# Altium schematic sheet Frequency sound amplifier_Schematic.SchDoc("Frequency sound amplifier_Schematic")
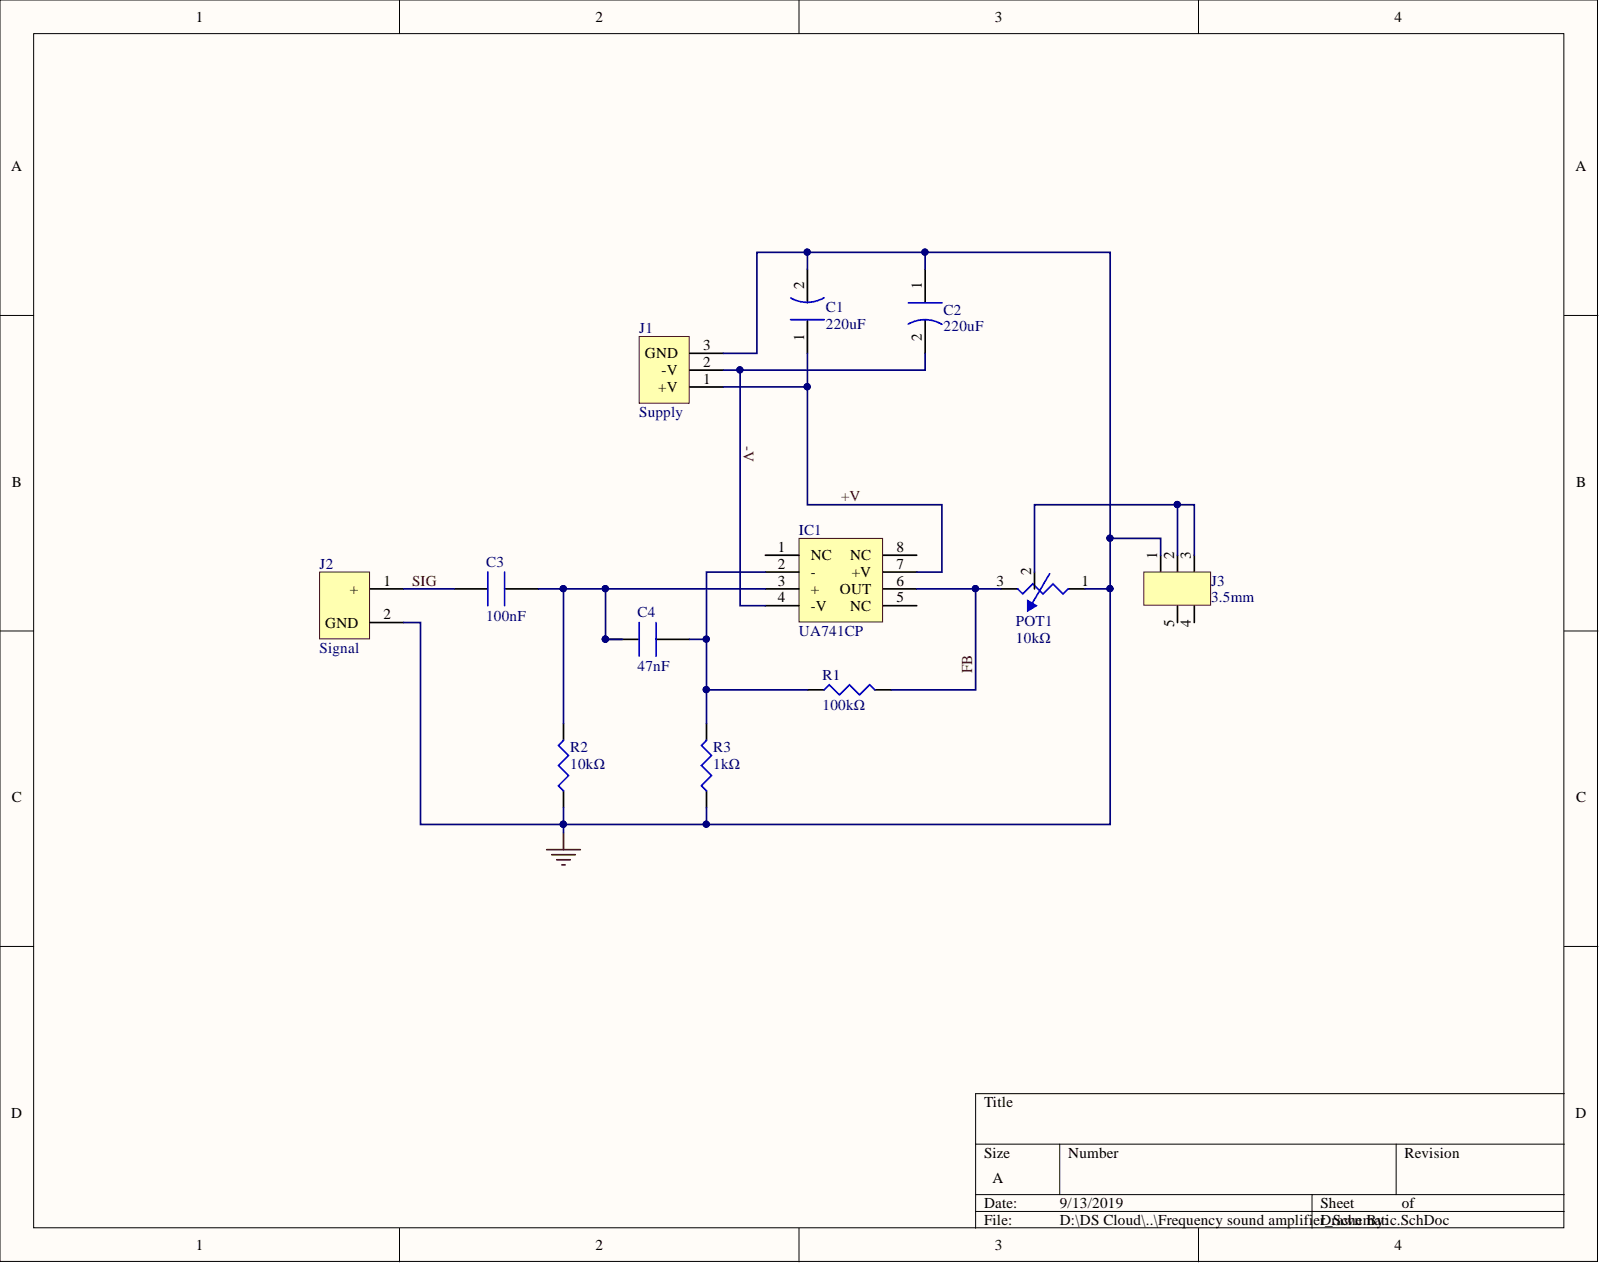
[diagram p0: Frequency sound amplifier_Schematic.SchDoc]

## Parts
C1  220uF  [220u]
C2  220uF  [220u]
C3  100nF  [100n]
C4  47nF  [47n]
IC1  UA741CP  [UE741]
J1  Supply  [Con3]
J2  Signal  [Con2]
J3  3.5mm  [3.5mm]
POT1  10kΩ  [Pot]
R1  100kΩ  [100k]
R2  10kΩ  [10K]
R3  1kΩ  [1k]

## Nets
GND: C1.2 C2.1 J1.3 J2.2 J3.1 POT1.1 R2.1 R3.1
+V: C1.1 IC1.7(+V) J1.1
-V: C2.2 IC1.4(-V) J1.2
FB: IC1.6(OUT) POT1.3 R1.2
SIG: C3.1 J2.1
NetC3_2: C3.2 C4.1 IC1.3(+) R2.2
NetC4_2: C4.2 IC1.2(-) R1.1 R3.2
NetJ3_2: J3.2 J3.3 POT1.2

Not connected: IC1 1(NC) 5(NC) 8(NC); J3 4 5

## Library data of the parts
C1 C2 = UVR1E221MPD1TA: Aluminum Electrolytic Capacitors - Leaded 25volts 220uF; Manufacturer: Nichicon; Voltage Rating (DC): 25V; Tolerance: 20%; Ripple Current: 330mA; Life (Hours): 2000Hour; Leakage Current: 165uA; Dissipation Factor: 0.16%; Dielectric Material: Aluminium; Voltage Rating: 25V; Termination: Radial; Supplier Part Number 1: 493-6097-1-ND; Supplier 1: Digi-Key; Stock 1: 2078; RoHS: Yes; Pricing 1: 1 = 0.29000, 10 = 0.20200, 100 = 0.12140, 500 = 0.09108 (USD); Price Per Unit: 0.29; Packaging: Tape and Reel; Package Quantity: 1; Number of Pins: 2; Mount: Through Hole; Min Operating Temperature: -40°C; Max Operating Temperature: 85°C; Manufacturer Part Number: UVR1E221MPD1TA; Length: 11.5mm; Lead Pitch: 0.197inch; Lead Free: Lead Free; Height - Seated (Max): 0.512inch; Features: General Purpose; ComponentLink2URL: https://datasheet.ciiva.com/6299/e-vr-6299007.pdf?src-supplier=Digi-Key; ComponentLink2Description: https://datasheet.ciiva.com/6299/e-vr-6299007.pdf?src-supplier=Digi-Key; ComponentLink1URL: https://datasheet.ciiva.com/16502/e-vr-16502829.pdf?src-supplier=Future+Electronics; ComponentLink1Description: https://datasheet.ciiva.com/16502/e-vr-16502829.pdf?src-supplier=Future+Electronics; Component Kind: Standard; Category: Capacitors; Case/Package: Radial; Capacitance: 220uF; Library Name: Frequency sound amplifier_SchematicLib.SchLib
C3 = C320C104K5R5TA7301: Cap Ceramic 0.1uF 50V X7R 10% Radial 2.54mm 125Â°C T/R; Manufacturer: KEMET; Lead Diameter: 0.51mm; Lead/Base Style: Straight; Dissipation Factor: 2.5%; Width: 5.08mm; Voltage Rating (DC): 50V; Voltage Rating: 50V; Tolerance: 10%; Termination: Radial; Supplier Part Number 1: 399-9870-1-ND; Supplier 1: Digi-Key; Stock 1: 99779; RoHS: Yes; Radiation Hardening: No; Pricing 1: 1 = 0.25000, 10 = 0.17300, 100 = 0.09780, 500 = 0.06900, 1000 = 0.06038 (USD); Price Per Unit: 0.25; Pitch: 2.54mm; Packaging: Tape and Reel; Package Quantity: 2500; Mount: Through Hole; Min Operating Temperature: -55°C; Max Operating Temperature: 125°C; Manufacturer Part Number: C320C104K5R5TA7301; Length: 5.08mm; Lead Pitch: 2.54mm; Height - Seated (Max): 0.26inch; Height: 3.18mm; Dielectric: X7R; Depth: 3.18mm; Contact Plating: Tin,Matte; ComponentLink2URL: https://datasheet.ciiva.com/6276/f3101-goldmax-6276795.pdf?src-supplier=Digi-Key; ComponentLink2Description: https://datasheet.ciiva.com/6276/f3101-goldmax-6276795.pdf?src-supplier=Digi-Key; ComponentLink1URL: https://datasheet.ciiva.com/6276/f3101-goldmax-6276793.pdf?src-supplier=Digi-Key; ComponentLink1Description: https://datasheet.ciiva.com/6276/f3101-goldmax-6276793.pdf?src-supplier=Digi-Key; Component Kind: Standard; Category: Capacitors; Case/Package: Dipped; Capacitance: 100nF; Library Name: Frequency sound amplifier_SchematicLib.SchLib
C4 = C315C473M5U5TA: CAP CER 0.047UF 50V Z5U RADIAL; Manufacturer: KEMET; Tolerance: 20%; Temperature Coefficient: 7.5ppm/°C; Lead Length: 7mm; Lead Diameter: 0.51mm; Lead/Base Style: Straight; Dissipation Factor: 4%; Dielectric Material: Ceramic; Width: 3.81mm; Voltage Rating (DC): 50V; Voltage Rating: 50V; Voltage: 50V; Termination: Radial; Supplier Part Number 1: 399-4189-ND; Supplier 1: Digi-Key; Stock 1: 1403; RoHS: Yes; REACH SVHC: No SVHC; Radiation Hardening: No; Pricing 1: 1 = 0.33000, 10 = 0.22800, 100 = 0.12920, 500 = 0.09120, 1000 = 0.07980, 2500 = 0.07600, 5000 = 0.07030 (USD); Price Per Unit: 0.33; Packaging: Bulk; Package Quantity: 500; Number of Pins: 2; Mount: Through Hole,PCB; Min Operating Temperature: -55°C; Max Operating Temperature: 85°C; Manufacturer Part Number: C315C473M5U5TA; Length: 3.81mm; Lead Pitch: 2.54mm; Lead Free: Lead Free; Height - Seated (Max): 0.21inch; Height: 2.54mm; Dielectric: Z5U; Depth: 2.54mm; Composition: Ceramic; ComponentLink9URL: https://search.kemet.com/component-edge/download/datasheet/C315C473M5U5TA.pdf?src-supplier=Digi-Key; ComponentLink9Description: https://search.kemet.com/component-edge/download/datasheet/C315C473M5U5TA.pdf?src-supplier=Digi-Key; ComponentLink8URL: http://capacitoredge.kemet.com/capedge2/DataSheet?pn=C315C473M5U5CA&src-supplier=Avnet; ComponentLink8Description: http://capacitoredge.kemet.com/capedge2/DataSheet?pn=C315C473M5U5CA&src-supplier=Avnet; ComponentLink7URL: http://capacitoredge.kemet.com/capedge2/DataSheet?pn=C315C473M5U5CA&src-supplier=Avnet+Europe; ComponentLink7Description: http://capacitoredge.kemet.com/capedge2/DataSheet?pn=C315C473M5U5CA&src-supplier=Avnet+Europe; ComponentLink6URL: http://www.kemet.com/kemet/web/homepage/kechome.nsf/file/Ceramic%20Conformally%20Coated%20Radial%20Standard%20and%20High%20Voltage%20Golden%20Max/$file/F3101_goldmax.pdf?src-supplier=Element14; ComponentLink6Description: http://www.kemet.com/kemet/web/homepage/kechome.nsf/file/Ceramic%20Conformally%20Coated%20Radial%20Standard%20and%20High%20Voltage%20Golden%20Max/$file/F3101_goldmax.pdf?src-supplier=Element14; ComponentLink5URL: http://components.arrow.com/part/dataview/42164590S8620817N7429?src-supplier=Arrow; ComponentLink5Description: http://components.arrow.com/part/dataview/42164590S8620817N7429?src-supplier=Arrow; ComponentLink4URL: http://uk.rs-online.com/webdocs/13da/0900766b813da575.pdf?src-supplier=RSComponents; ComponentLink4Description: http://uk.rs-online.com/webdocs/13da/0900766b813da575.pdf?src-supplier=RSComponents; ComponentLink3URL: https://www.alliedelec.com/m/d/fcfab52016b7968de19fc4f2d27edd4c.pdf?src-supplier=Allied+Electronics; ComponentLink3Description: https://www.alliedelec.com/m/d/fcfab52016b7968de19fc4f2d27edd4c.pdf?src-supplier=Allied+Electronics; ComponentLink2URL: http://www.farnell.com/datasheets/706880.pdf?src-supplier=Farnell; ComponentLink2Description: http://www.farnell.com/datasheets/706880.pdf?src-supplier=Farnell; ComponentLink1URL: http://www.mouser.com/ds/2/212/KEM_C1051_GOLDMAX_Z5U-1140498.pdf?src-supplier=Mouser; ComponentLink1Description: http://www.mouser.com/ds/2/212/KEM_C1051_GOLDMAX_Z5U-1140498.pdf?src-supplier=Mouser; Component Kind: Standard; Category: Capacitors; Case/Package: Radial; Capacitance: 47nF; Library Name: Frequency sound amplifier_SchematicLib.SchLib
IC1 = LM741CN/NOPB: Operational amplifier; 1.5MHz; 10÷36V; Channels: 1; DIP8; Manufacturer: TI National Semiconductor; Voltage Gain: 106.02dB; Voltage: 36V; Slew Rate: 0.5V/µs; Power Supply Rejection Ratio (PSRR): 77dB; Power Dissipation: 500mW; Output Current per Channel: 25mA; Operating Supply Current: 1.7mA; Number of Elements: 1; Min Supply Voltage: 10V; Min Dual Supply Voltage: 5V; Max Supply Voltage: 18V; Max Supply Current: 2.8mA; Input Offset Voltage (Vos): 2mV; Input Bias Current: 500nA; Gain: 96dB; Common Mode Rejection Ratio: 70dB; Width: 6.35mm; Weight: 0.01lb; Supplier Part Number 1: LM741CNNS/NOPB-ND; Supplier 1: Digi-Key; Stock 1: 9440; RoHS: Yes; REACH SVHC: No SVHC; Radiation Hardening: No; Pricing 1: 1 = 0.87000, 10 = 0.76600, 25 = 0.71920, 100 = 0.58700, 500 = 0.46400, 1000 = 0.37120, 2500 = 0.33640, 5000 = 0.31320 (USD); Price Per Unit: 0.87; Packaging: Rail/Tube; Package Quantity: 40; Output Current: 25mA; Number of Pins: 8; Number of Circuits: 1; Number of Channels: 1; Number of Amplifiers: 1; Nominal Supply Current: 1.7mA; Mount: Through Hole; Min Operating Temperature: 0°C; Max Power Dissipation: 500mW; Max Operating Temperature: 70°C; Max Dual Supply Voltage: 18V; Manufacturer Part Number: LM741CN/NOPB; Length: 9.27mm; Lead Free: Lead Free; Height: 3.3mm; Gain Bandwidth Product: 1.5MHz; Contact Plating: Tin,Matte; ComponentLink4URL: https://datasheet.ciiva.com/14151/mflm741-data-e-14151370.pdf?src-supplier=Distrelec; ComponentLink4Description: https://datasheet.ciiva.com/14151/mflm741-data-e-14151370.pdf?src-supplier=Distrelec; ComponentLink3URL: https://datasheet.ciiva.com/8008/1862441-8008933.pdf?src-supplier=Element14; ComponentLink3Description: https://datasheet.ciiva.com/8008/1862441-8008933.pdf?src-supplier=Element14; ComponentLink2URL: https://datasheet.ciiva.com/26325/175935-da-01-en-ic-lm-741cn-nopb-dip8-tid-26325918.pdf?src-supplier=Conrad; ComponentLink2Description: https://datasheet.ciiva.com/26325/175935-da-01-en-ic-lm-741cn-nopb-dip8-tid-26325918.pdf?src-supplier=Conrad; ComponentLink1URL: https://datasheet.ciiva.com/26850/lm741cn-nopb-26850677.pdf?src-supplier=IIIC; ComponentLink1Description: https://datasheet.ciiva.com/26850/lm741cn-nopb-26850677.pdf?src-supplier=IIIC; Component Kind: Standard; Category: IC; Case/Package: DIP; Bandwidth: 1.5MHz; Library Name: Frequency sound amplifier_SchematicLib.SchLib
J1 = 282834-3: TERM BLK 3P SIDE ENT 2.54MM PCB; Manufacturer: TE Connectivity; Wire Gauge (Min): 30AWG; Voltage Rating: 150V; Thread Size: M1.6; Tail Length: 3.4mm; Side Stackable: Yes (With); Screw Flange: No (Without); Plating: Brass,Steel; Number of Positions Per Level: 3; Number of Levels: 1; Max Current Rating: 10A; Connector Type: Receptacle; Approvals: UL; Wire Gauge (Max): 30AWG; Wire/Cable Gauge: 30AWG; Width: 10mm; Voltage: 150V; Termination: Solder; Supplier Part Number 1: A98334-ND; Supplier 1: Digi-Key; Stock 1: 3174; Screwless Terminal Block: Yes (With); RoHS: Yes; REACH SVHC: Unknown; Radiation Hardening: No; Pricing 1: 1 = 2.01000, 10 = 1.52300, 25 = 1.41160, 50 = 1.26920, 100 = 1.23820, 250 = 1.08340, 500 = 0.99052, 1000 = 0.89767, 5000 = 0.78933 (USD); Price Per Unit: 2.01; Pitch: 2.54mm; Packaging: Bulk; Package Quantity: 200; Orientation: Straight; Number of Rows: 1; Number of Positions: 3; Number of Poles: 3; Number of Contacts: 3; Number of Circuits: 3; Mount: Through Hole; Min Operating Temperature: -40°C; Max Voltage Rating (AC): 150V; Max Supply Voltage (AC): 150V; Max Operating Temperature: 105°C; Manufacturer Part Number: 282834-3; Length: 8.08mm; Lead Free: Lead Free; Interlock: No (Without); Housing Material: Polyamide; Housing Color: Green; Flammability Rating: UL94 V-0; ELV: Compliant; Depth: 6.5mm; Current Rating: 10A; Current: 10A; Contact Plating: Tin; Contact Material: Brass; ComponentLink8URL: https://datasheet.ciiva.com/16469/eng-cs-1308389-eurostyle-terminal-blocks-0607-16469502.pdf?src-supplier=Avnet+Asia; ComponentLink8Description: https://datasheet.ciiva.com/16469/eng-cs-1308389-eurostyle-terminal-blocks-0607-16469502.pdf?src-supplier=Avnet+Asia; ComponentLink7URL: https://datasheet.ciiva.com/14610/70087777-14610266.pdf?src-supplier=Allied+Electronics; ComponentLink7Description: https://datasheet.ciiva.com/14610/70087777-14610266.pdf?src-supplier=Allied+Electronics; ComponentLink6URL: https://datasheet.ciiva.com/7978/583107-7978559.pdf?src-supplier=Element14; ComponentLink6Description: https://datasheet.ciiva.com/7978/583107-7978559.pdf?src-supplier=Element14; ComponentLink5URL: https://datasheet.ciiva.com/3141/eng-cs-1308389-eurostyle-terminal-blocks-0607-3141116.pdf?src-supplier=Avnet; ComponentLink5Description: https://datasheet.ciiva.com/3141/eng-cs-1308389-eurostyle-terminal-blocks-0607-3141116.pdf?src-supplier=Avnet; ComponentLink4URL: https://datasheet.ciiva.com/823/eng-cd-282834-c1-823464.pdf?src-supplier=Digi-Key; ComponentLink4Description: https://datasheet.ciiva.com/823/eng-cd-282834-c1-823464.pdf?src-supplier=Digi-Key; ComponentLink3URL: https://datasheet.ciiva.com/30217/eng-cs-1308389-eurostyle-terminal-blocks-0607-30217495.pdf?src-supplier=Onlinecomponents.com; ComponentLink3Description: https://datasheet.ciiva.com/30217/eng-cs-1308389-eurostyle-terminal-blocks-0607-30217495.pdf?src-supplier=Onlinecomponents.com; ComponentLink2URL: https://datasheet.ciiva.com/15169/getdatasheetpartid-155865-15169559.pdf?src-supplier=Verical; ComponentLink2Description: https://datasheet.ciiva.com/15169/getdatasheetpartid-155865-15169559.pdf?src-supplier=Verical; ComponentLink1URL: https://datasheet.ciiva.com/11757/0900766b80f924bc-11757569.pdf?src-supplier=RSComponents; ComponentLink1Description: https://datasheet.ciiva.com/11757/0900766b80f924bc-11757569.pdf?src-supplier=RSComponents; Component Kind: Standard; Color: Green; Closed End: No (Without); Category: Connectors; Library Name: Frequency sound amplifier_SchematicLib.SchLib
J2 = 282834-2: TERM BLK 2P SIDE ENT 2.54MM PCB; Manufacturer: TE Connectivity; Wire Gauge (Min): 30AWG; Voltage Rating: 150V; Thread Size: M1.6; Tail Length: 3.4mm; Side Stackable: Yes (With); Screw Flange: No (Without); Proce Per Unit: 0.88; Plating: Brass,Steel; Number of Positions Per Level: 2; Number of Levels: 1; Max Current Rating: 10A; Gender: Male; Connector Type: Receptacle; Approvals: UL; Wire Gauge (Max): 30AWG; Wire/Cable Gauge: 30AWG; Width: 6.5mm; Voltage: 150V; Termination: Solder; Supplier Part Number 1: A98333-ND; Supplier 1: Digi-Key; Stock 1: 38412; Screwless Terminal Block: Yes (With); RoHS: Yes; REACH SVHC: Unknown; Radiation Hardening: No; Pricing 1: 1 = 0.88000, 10 = 0.62000, 25 = 0.57840, 50 = 0.52500, 100 = 0.52280, 250 = 0.44144, 500 = 0.41822, 1000 = 0.37175, 5000 = 0.34852 (USD); Price Per Unit: 0.88; Pitch: 2.54mm; Packaging: Bulk; Package Quantity: 300; Orientation: Straight; Number of Rows: 1; Number of Positions: 2; Number of Poles: 2; Number of Contacts: 2; Number of Circuits: 2; Mount: Through Hole; Min Operating Temperature: -40°C; Max Voltage Rating (AC): 150V; Max Supply Voltage (AC): 150V; Max Operating Temperature: 105°C; Material: Nylon; Manufacturer Part Number: 282834-2; Length: 5.54mm; Lead Free: Lead Free; Interlock: No (Without); Housing Material: Polyamide; Housing Color: Green; Flammability Rating: UL94 V-0; ELV: Compliant; Depth: 6.5mm; Current Rating: 10A; Current: 10A; Contact Plating: Tin; Contact Material: Brass; ComponentLink8URL: https://datasheet.ciiva.com/16676/eng-cs-1308389-eurostyle-terminal-blocks-0607-16676126.pdf?src-supplier=Avnet+Europe; ComponentLink8Description: https://datasheet.ciiva.com/16676/eng-cs-1308389-eurostyle-terminal-blocks-0607-16676126.pdf?src-supplier=Avnet+Europe; ComponentLink7URL: https://datasheet.ciiva.com/30166/eng-cs-1308389-eurostyle-terminal-blocks-0607-30166974.pdf?src-supplier=Onlinecomponents.com; ComponentLink7Description: https://datasheet.ciiva.com/30166/eng-cs-1308389-eurostyle-terminal-blocks-0607-30166974.pdf?src-supplier=Onlinecomponents.com; ComponentLink6URL: https://datasheet.ciiva.com/823/eng-cd-282834-c1-823453.pdf?src-supplier=Digi-Key; ComponentLink6Description: https://datasheet.ciiva.com/823/eng-cd-282834-c1-823453.pdf?src-supplier=Digi-Key; ComponentLink5URL: https://datasheet.ciiva.com/3141/eng-cs-1308389-eurostyle-terminal-blocks-0607-3141115.pdf?src-supplier=Avnet; ComponentLink5Description: https://datasheet.ciiva.com/3141/eng-cs-1308389-eurostyle-terminal-blocks-0607-3141115.pdf?src-supplier=Avnet; ComponentLink4URL: https://datasheet.ciiva.com/15169/getdatasheetpartid-155864-15169558.pdf?src-supplier=Verical; ComponentLink4Description: https://datasheet.ciiva.com/15169/getdatasheetpartid-155864-15169558.pdf?src-supplier=Verical; ComponentLink3URL: https://datasheet.ciiva.com/14609/70086732-14609670.pdf?src-supplier=Allied+Electronics; ComponentLink3Description: https://datasheet.ciiva.com/14609/70086732-14609670.pdf?src-supplier=Allied+Electronics; ComponentLink2URL: https://datasheet.ciiva.com/16386/282834-2-16386363.pdf?src-supplier=Future+Electronics; ComponentLink2Description: https://datasheet.ciiva.com/16386/282834-2-16386363.pdf?src-supplier=Future+Electronics; ComponentLink1URL: https://datasheet.ciiva.com/11758/0900766b80e7dc96-11758675.pdf?src-supplier=RSComponents; ComponentLink1Description: https://datasheet.ciiva.com/11758/0900766b80e7dc96-11758675.pdf?src-supplier=RSComponents; Component Kind: Standard; Color: Green; Closed End: No (Without); Category: Connectors; Library Name: Frequency sound amplifier_SchematicLib.SchLib
J3 = SJ1-3524NG: CONN JACK STEREO 3.5MM R/A; Manufacturer: CUI; Shielding: Unshielded; Number of Ports: 1; Number of Conductors: 3; Gender: Female; Current Rating: 1A; Termination: Solder; Supplier Part Number 1: CP1-3524NG-ND; Supplier 1: Digi-Key; Stock 1: 10222; RoHS: Yes; Pricing 1: 1 = 0.81000, 10 = 0.70900, 25 = 0.66720, 50 = 0.63940, 100 = 0.61160, 250 = 0.55600, 500 = 0.50040, 1000 = 0.44480, 2500 = 0.40310 (USD); Price Per Unit: 0.81; Packaging: Bulk; Orientation: Horizontal; Mount: Through Hole; Manufacturer Part Number: SJ1-3524NG; Lead Free: Lead Free; Diameter: 3.5mm; Contact Plating: Tin; Connector Type: Jack; ComponentLink1URL: https://www.cui.com/product/resource/digikeypdf/sj1-352xng_series.pdf?src-supplier=Digi-Key; ComponentLink1Description: https://www.cui.com/product/resource/digikeypdf/sj1-352xng_series.pdf?src-supplier=Digi-Key; Component Kind: Standard; Color: Black; Category: Connectors; Library Name: Frequency sound amplifier_SchematicLib.SchLib
POT1 = P160KN-0QD15B1K: POT 1K OHM 1/5W PLASTIC LINEAR; Manufacturer: TT BI; Tolerance: 20%; Resistance: 1kR; Power Rating: 200mW; Number of Turns: 1; Actuator Length: 0.591inch; Actuator Diameter: 0.236inch; Termination: PCB; Taper: Linear; Supplier Part Number 1: 987-1309-ND; Supplier 1: Digi-Key; Stock 1: 722; RoHS: Yes; Pricing 1: 1 = 0.79000, 10 = 0.71300, 25 = 0.64400, 50 = 0.62100, 100 = 0.58650, 300 = 0.56350, 600 = 0.52900, 1200 = 0.43700, 5100 = 0.35650 (USD); Price Per Unit: 0.79; Packaging: Tray; Package Quantity: 300; Number of Gangs: 1; Mount: Panel; Min Operating Temperature: -20°C; Max Power Dissipation: 200mW; Max Operating Temperature: 70°C; Manufacturer Part Number: P160KN-0QD15B1K; Diameter: 16.5mm; Composition: Conductive Plastic; ComponentLink1URL: https://datasheet.ciiva.com/4992/p160-20748-4992552.pdf?src-supplier=Mouser; ComponentLink1Description: https://datasheet.ciiva.com/4992/p160-20748-4992552.pdf?src-supplier=Mouser; Component Kind: Standard; Category: Rotary Potentiometers; Library Name: Frequency sound amplifier_SchematicLib.SchLib
R1 = RNF14FTD100K: RES 100K OHM 1/4W 1% AXIAL; Manufacturer: Stackpole Electronics; Temperature Coefficient: 100ppm/°C; Resistance: 100kR; Power Rating: 250mW; Tolerance: 1%; Termination: Axial; Supplier Part Number 1: RNF14FTD100KCT-ND; Supplier 1: Digi-Key; Stock 1: 57740; RoHS: Yes; Radiation Hardening: No; Pricing 1: 1 = 0.10000, 10 = 0.07200, 25 = 0.04960, 50 = 0.03860, 100 = 0.02750, 250 = 0.02144, 500 = 0.01706, 1000 = 0.01210 (USD); Price Per Unit: 0.1; Packaging: Tape and Reel; Package Quantity: 1; Number of Terminations: 2; Mount: Through Hole,Axial; Min Operating Temperature: -55°C; Military Standard: Not; Max Power Dissipation: 250mW; Max Operating Temperature: 155°C; Manufacturer Part Number: RNF14FTD100K; Length: 6.35mm; Lead Free: Lead Free; Features: Flame Retardant Coating; Diameter: 2.35mm; Contact Plating: Copper,Tin; Composition: Metal Film; ComponentLink4URL: http://www.seielect.com/Catalog/SEI-RNF_RNMF.pdf?src-supplier=Future+Electronics; ComponentLink4Description: http://www.seielect.com/Catalog/SEI-RNF_RNMF.pdf?src-supplier=Future+Electronics; ComponentLink3URL: https://www.verical.com/server-webapp/html/getDatasheet?partID=364266&src-supplier=Verical; ComponentLink3Description: https://www.verical.com/server-webapp/html/getDatasheet?partID=364266&src-supplier=Verical; ComponentLink2URL: https://www.seielect.com/Catalog/SEI-RNF_RNMF.pdf?src-supplier=Avnet; ComponentLink2Description: https://www.seielect.com/Catalog/SEI-RNF_RNMF.pdf?src-supplier=Avnet; ComponentLink1URL: https://www.seielect.com/Catalog/SEI-RNF_RNMF.pdf?src-supplier=Digi-Key; ComponentLink1Description: https://www.seielect.com/Catalog/SEI-RNF_RNMF.pdf?src-supplier=Digi-Key; Component Kind: Standard; Category: Resistors; Case/Package: Conformal; Library Name: Frequency sound amplifier_SchematicLib.SchLib
R2 = RNF14FTD10K0: RES 10K OHM 1/4W 1% AXIAL; Manufacturer: Stackpole Electronics; Temperature Coefficient: 100ppm/°C; Resistance: 10kR; Power Rating: 250mW; Tolerance: 1%; Termination: Axial; Supplier Part Number 1: RNF14FTD10K0CT-ND; Supplier 1: Digi-Key; Stock 1: 59229; RoHS: Yes; Pricing 1: 1 = 0.10000, 10 = 0.07200, 25 = 0.04960, 50 = 0.03860, 100 = 0.02750, 250 = 0.02144, 500 = 0.01706, 1000 = 0.01210 (USD); Price Per Unit: 0.1; Packaging: Tape and Reel; Package Quantity: 1; Number of Terminations: 2; Mount: Through Hole,Axial; Min Operating Temperature: -55°C; Military Standard: Not; Max Power Dissipation: 250mW; Max Operating Temperature: 155°C; Manufacturer Part Number: RNF14FTD10K0; Length: 6.35mm; Lead Free: Lead Free; Features: Flame Retardant Coating; Diameter: 2.35mm; Contact Plating: Copper,Tin; Composition: Metal Film; ComponentLink4URL: https://www.seielect.com/Catalog/SEI-RNF_RNMF.pdf?src-supplier=Avnet; ComponentLink4Description: https://www.seielect.com/Catalog/SEI-RNF_RNMF.pdf?src-supplier=Avnet; ComponentLink3URL: https://www.verical.com/server-webapp/html/getDatasheet?partID=359922&src-supplier=Verical; ComponentLink3Description: https://www.verical.com/server-webapp/html/getDatasheet?partID=359922&src-supplier=Verical; ComponentLink2URL: http://www.seielect.com/Catalog/SEI-RNF_RNMF.pdf?src-supplier=Future+Electronics; ComponentLink2Description: http://www.seielect.com/Catalog/SEI-RNF_RNMF.pdf?src-supplier=Future+Electronics; ComponentLink1URL: https://www.seielect.com/Catalog/SEI-RNF_RNMF.pdf?src-supplier=Digi-Key; ComponentLink1Description: https://www.seielect.com/Catalog/SEI-RNF_RNMF.pdf?src-supplier=Digi-Key; Component Kind: Standard; Category: Resistors; Case/Package: Conformal; Library Name: Frequency sound amplifier_SchematicLib.SchLib
R3 = RNF14FTD1K00: RES 1K OHM 1/4W 1% AXIAL; Manufacturer: Stackpole Electronics; Temperature Coefficient: 100ppm/°C; Resistance: 1kR; Power Rating: 250mW; Tolerance: 1%; Termination: Axial; Supplier Part Number 1: RNF14FTD1K00CT-ND; Supplier 1: Digi-Key; Stock 1: 312551; RoHS: Yes; Radiation Hardening: No; Pricing 1: 1 = 0.10000, 10 = 0.07200, 25 = 0.04960, 50 = 0.03860, 100 = 0.02750, 250 = 0.02144, 500 = 0.01706, 1000 = 0.01210 (USD); Price Per Unit: 0.1; Packaging: Tape and Reel; Package Quantity: 1; Number of Terminations: 2; Mount: Through Hole,Axial; Min Operating Temperature: -55°C; Military Standard: Not; Max Power Dissipation: 250mW; Max Operating Temperature: 155°C; Manufacturer Part Number: RNF14FTD1K00; Length: 6.35mm; Lead Free: Lead Free; Features: Flame Retardant Coating; Diameter: 2.35mm; Contact Plating: Copper,Tin; Composition: Metal Film; ComponentLink5URL: http://www.seielect.com/Catalog/SEI-RNF_RNMF.pdf?src-supplier=Future+Electronics; ComponentLink5Description: http://www.seielect.com/Catalog/SEI-RNF_RNMF.pdf?src-supplier=Future+Electronics; ComponentLink4URL: https://www.verical.com/server-webapp/html/getDatasheet?partID=360720&src-supplier=Verical; ComponentLink4Description: https://www.verical.com/server-webapp/html/getDatasheet?partID=360720&src-supplier=Verical; ComponentLink3URL: https://www.seielect.com/Catalog/SEI-RNF_RNMF.pdf?src-supplier=Digi-Key; ComponentLink3Description: https://www.seielect.com/Catalog/SEI-RNF_RNMF.pdf?src-supplier=Digi-Key; ComponentLink2URL: https://www.seielect.com/Catalog/SEI-RNF_RNMF.pdf?src-supplier=Avnet; ComponentLink2Description: https://www.seielect.com/Catalog/SEI-RNF_RNMF.pdf?src-supplier=Avnet; ComponentLink1URL: http://components.arrow.com/part/dataview/50573548S9848899N1174?src-supplier=Arrow; ComponentLink1Description: http://components.arrow.com/part/dataview/50573548S9848899N1174?src-supplier=Arrow; Component Kind: Standard; Category: Resistors; Case/Package: Conformal; Library Name: Frequency sound amplifier_SchematicLib.SchLib
